# Altium schematic sheet PHOTODIODE.SchDoc
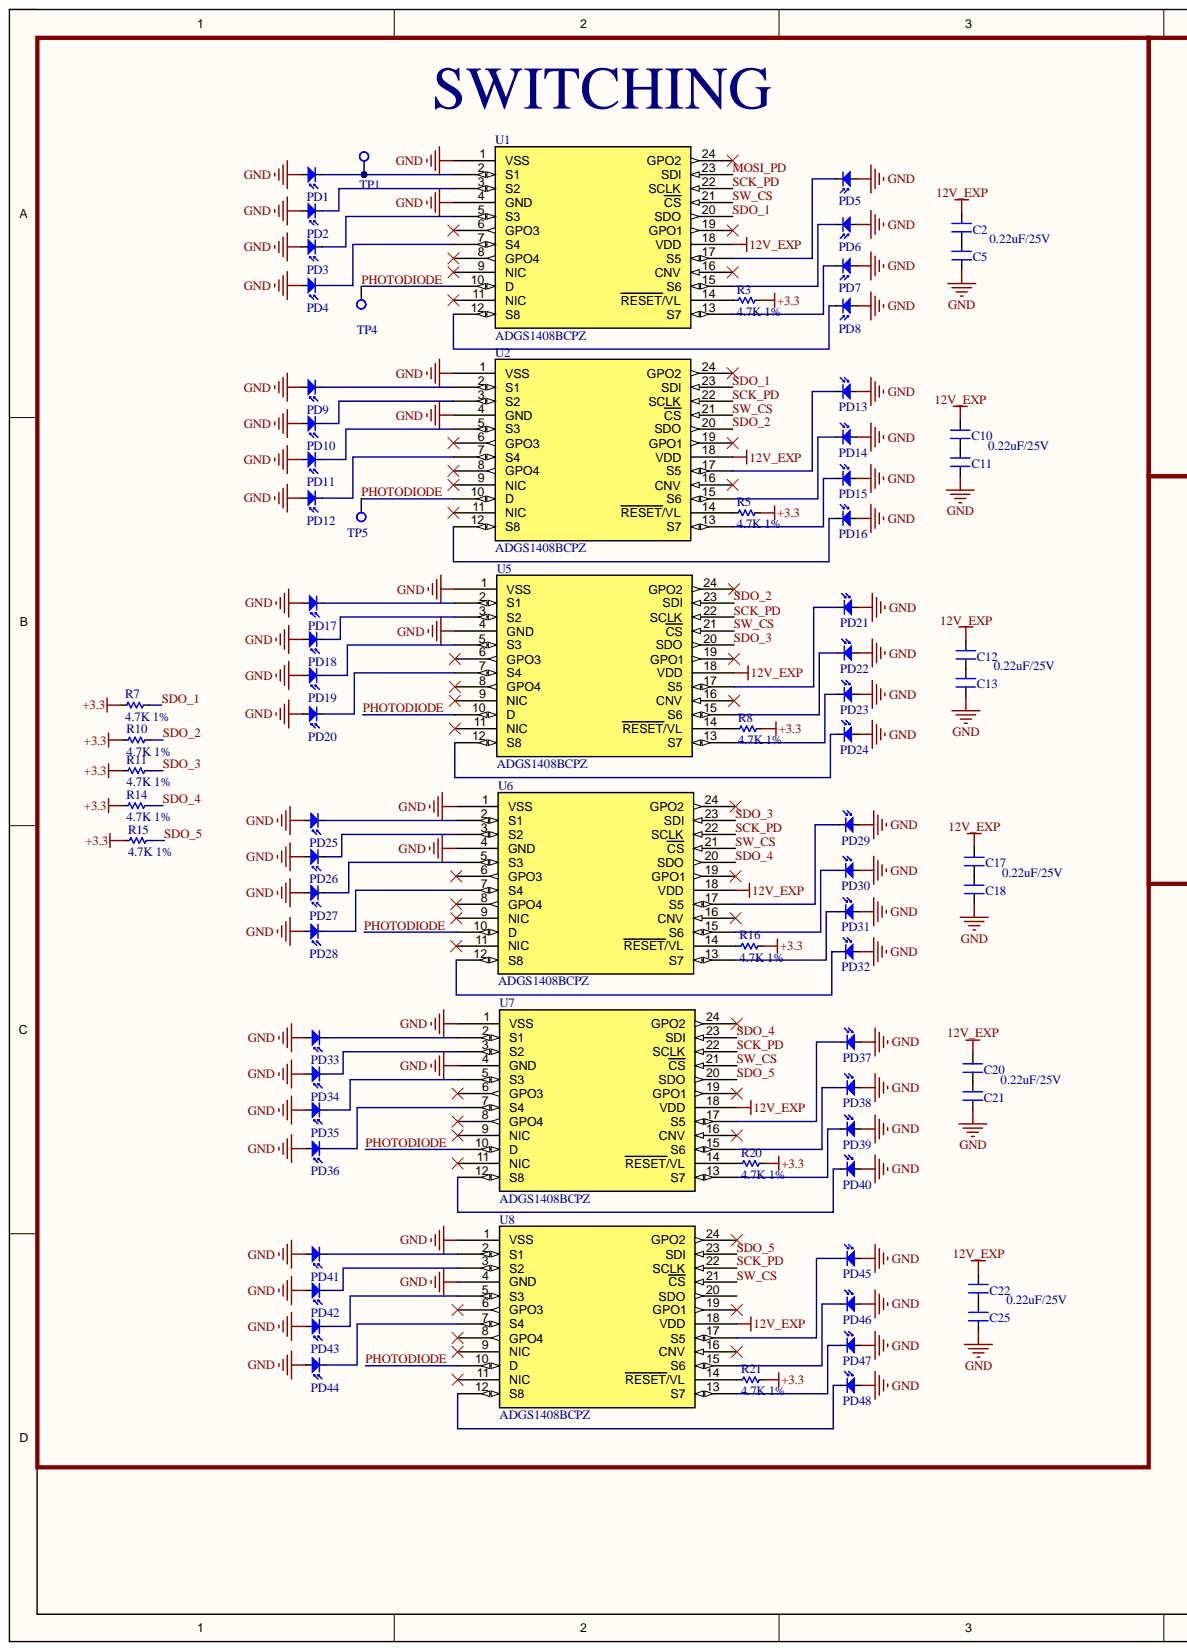
[diagram p0: PHOTODIODE.SchDoc, left]
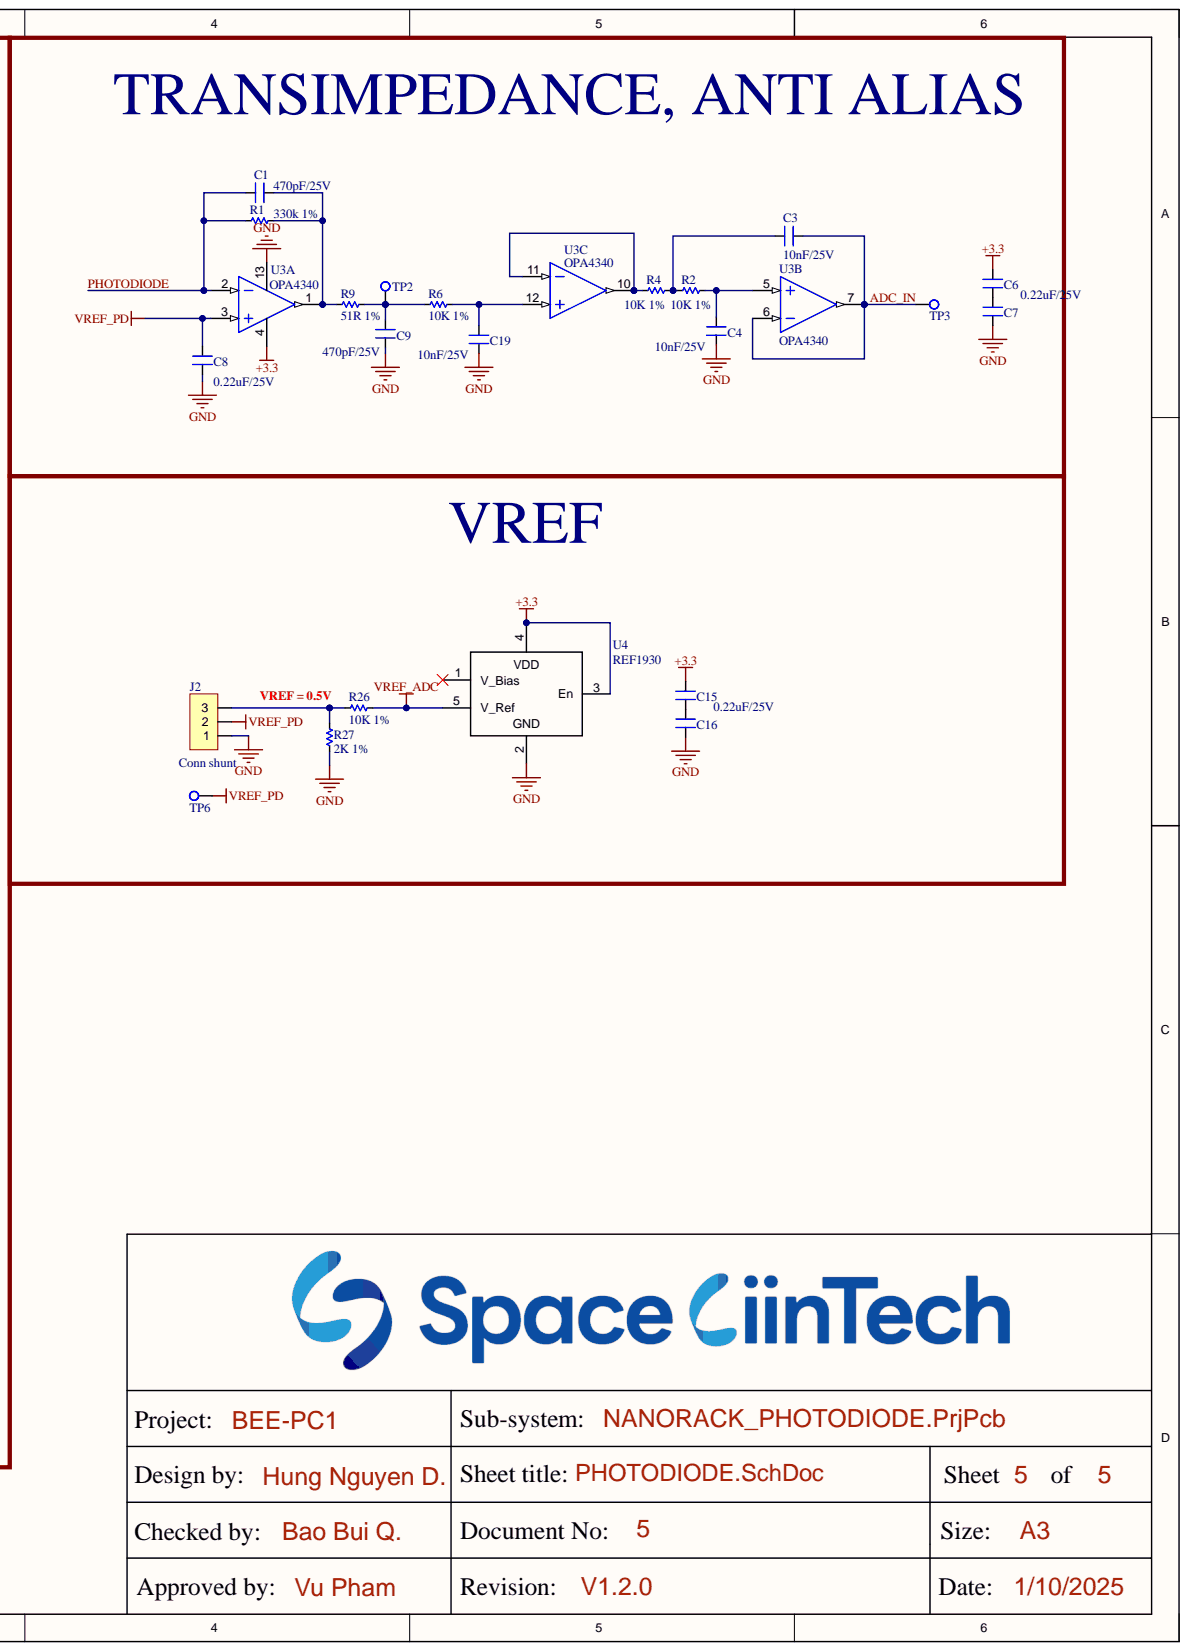
[diagram p1: PHOTODIODE.SchDoc, right]

## Parts
C1  470pF/25V  [SM-0603]
C2  0.22uF/25V  [SM-0603]
C3  10nF/25V  [SM-0603]
C4  10nF/25V  [SM-0603]
C5  0.22uF/25V  [SM-0603]
C6  0.22uF/25V  [SM-0603]
C7  0.22uF/25V  [SM-0603]
C8  0.22uF/25V  [SM-0603]
C9  470pF/25V  [SM-0603]
C10  0.22uF/25V  [SM-0603]
C11  0.22uF/25V  [SM-0603]
C12  0.22uF/25V  [SM-0603]
C13  0.22uF/25V  [SM-0603]
C15  0.22uF/25V  [SM-0603]
C16  0.22uF/25V  [SM-0603]
C17  0.22uF/25V  [SM-0603]
C18  0.22uF/25V  [SM-0603]
C19  10nF/25V  [SM-0603]
C20  0.22uF/25V  [SM-0603]
C21  0.22uF/25V  [SM-0603]
C22  0.22uF/25V  [SM-0603]
C25  0.22uF/25V  [SM-0603]
J2  Conn shunt  [M50-3930342]
PD1  Photodiode  [VEMD5080X01]  (value not drawn)
PD2  Photodiode  [VEMD5080X01]  (value not drawn)
PD3  Photodiode  [VEMD5080X01]  (value not drawn)
PD4  Photodiode  [VEMD5080X01]  (value not drawn)
PD5  Photodiode  [VEMD5080X01]  (value not drawn)
PD6  Photodiode  [VEMD5080X01]  (value not drawn)
PD7  Photodiode  [VEMD5080X01]  (value not drawn)
PD8  Photodiode  [VEMD5080X01]  (value not drawn)
PD9  Photodiode  [VEMD5080X01]  (value not drawn)
PD10  Photodiode  [VEMD5080X01]  (value not drawn)
PD11  Photodiode  [VEMD5080X01]  (value not drawn)
PD12  Photodiode  [VEMD5080X01]  (value not drawn)
PD13  Photodiode  [VEMD5080X01]  (value not drawn)
PD14  Photodiode  [VEMD5080X01]  (value not drawn)
PD15  Photodiode  [VEMD5080X01]  (value not drawn)
PD16  Photodiode  [VEMD5080X01]  (value not drawn)
PD17  Photodiode  [VEMD5080X01]  (value not drawn)
PD18  Photodiode  [VEMD5080X01]  (value not drawn)
PD19  Photodiode  [VEMD5080X01]  (value not drawn)
PD20  Photodiode  [VEMD5080X01]  (value not drawn)
PD21  Photodiode  [VEMD5080X01]  (value not drawn)
PD22  Photodiode  [VEMD5080X01]  (value not drawn)
PD23  Photodiode  [VEMD5080X01]  (value not drawn)
PD24  Photodiode  [VEMD5080X01]  (value not drawn)
PD25  Photodiode  [VEMD5080X01]  (value not drawn)
PD26  Photodiode  [VEMD5080X01]  (value not drawn)
PD27  Photodiode  [VEMD5080X01]  (value not drawn)
PD28  Photodiode  [VEMD5080X01]  (value not drawn)
PD29  Photodiode  [VEMD5080X01]  (value not drawn)
PD30  Photodiode  [VEMD5080X01]  (value not drawn)
PD31  Photodiode  [VEMD5080X01]  (value not drawn)
PD32  Photodiode  [VEMD5080X01]  (value not drawn)
PD33  Photodiode  [VEMD5080X01]  (value not drawn)
PD34  Photodiode  [VEMD5080X01]  (value not drawn)
PD35  Photodiode  [VEMD5080X01]  (value not drawn)
PD36  Photodiode  [VEMD5080X01]  (value not drawn)
PD37  Photodiode  [VEMD5080X01]  (value not drawn)
PD38  Photodiode  [VEMD5080X01]  (value not drawn)
PD39  Photodiode  [VEMD5080X01]  (value not drawn)
PD40  Photodiode  [VEMD5080X01]  (value not drawn)
PD41  Photodiode  [VEMD5080X01]  (value not drawn)
PD42  Photodiode  [VEMD5080X01]  (value not drawn)
PD43  Photodiode  [VEMD5080X01]  (value not drawn)
PD44  Photodiode  [VEMD5080X01]  (value not drawn)
PD45  Photodiode  [VEMD5080X01]  (value not drawn)
PD46  Photodiode  [VEMD5080X01]  (value not drawn)
PD47  Photodiode  [VEMD5080X01]  (value not drawn)
PD48  Photodiode  [VEMD5080X01]  (value not drawn)
R1  330k 1%  [RES-SMD-0603]
R2  10K 1%  [RES-SMD-0603]
R3  4.7K 1%  [RES-SMD-0603]
R4  10K 1%  [RES-SMD-0603]
R5  4.7K 1%  [RES-SMD-0603]
R6  10K 1%  [RES-SMD-0603]
R7  4.7K 1%  [RES-SMD-0603]
R8  4.7K 1%  [RES-SMD-0603]
R9  51R 1%  [RES-SMD-0603]
R10  4.7K 1%  [RES-SMD-0603]
R11  4.7K 1%  [RES-SMD-0603]
R14  4.7K 1%  [RES-SMD-0603]
R15  4.7K 1%  [RES-SMD-0603]
R16  4.7K 1%  [RES-SMD-0603]
R20  4.7K 1%  [RES-SMD-0603]
R21  4.7K 1%  [RES-SMD-0603]
R26  10K 1%  [RES-SMD-0603]
R27  2K 1%  [RES-SMD-0603]
TP1  Test_Point  [TESTPOINT]  (value not drawn)
TP2  Test_Point  [TESTPOINT]  (value not drawn)
TP3  Test_Point  [TESTPOINT]  (value not drawn)
TP4  Test_Point  [TESTPOINT]  (value not drawn)
TP5  Test_Point  [TESTPOINT]  (value not drawn)
TP6  Test_Point  [TESTPOINT]  (value not drawn)
U1  ADGS1408BCPZ  [ADGS1408BCPZ]
U2  ADGS1408BCPZ  [ADGS1408BCPZ]
U3  OPA4340  [SSOP16]  (parts A,B,C)
U4  REF1930  [SOT23-5]
U5  ADGS1408BCPZ  [ADGS1408BCPZ]
U6  ADGS1408BCPZ  [ADGS1408BCPZ]
U7  ADGS1408BCPZ  [ADGS1408BCPZ]
U8  ADGS1408BCPZ  [ADGS1408BCPZ]

## Nets
12V_EXP: C2.2 C10.2 C12.2 C17.2 C20.2 C22.2 U1.18(VDD) U2.18(VDD) U5.18(VDD) U6.18(VDD) U7.18(VDD) U8.18(VDD)
+3.3: C6.2 C15.2 R3.2 R5.2 R7.2 R8.2 R10.2 R11.2 R14.2 R15.2 R16.2 R20.2 R21.2 U3.4 U4.3(En) U4.4
GND: C4.1 C5.1 C7.1 C8.1 C9.1 C11.1 C13.1 C16.1 C18.1 C19.1 C21.1 C25.1 J2.1 PD1.1 PD2.1 PD3.1 PD4.1 PD5.1 PD6.1 PD7.1 PD8.1 PD9.1 PD10.1 PD11.1 PD12.1 PD13.1 PD14.1 PD15.1 PD16.1 PD17.1 PD18.1 PD19.1 PD20.1 PD21.1 PD22.1 PD23.1 PD24.1 PD25.1 PD26.1 PD27.1 PD28.1 PD29.1 PD30.1 PD31.1 PD32.1 PD33.1 PD34.1 PD35.1 PD36.1 PD37.1 PD38.1 PD39.1 PD40.1 PD41.1 PD42.1 PD43.1 PD44.1 PD45.1 PD46.1 PD47.1 PD48.1 R27.1 U1.1(VSS) U1.4(GND) U2.1(VSS) U2.4(GND) U3.13 U4.2 U5.1(VSS) U5.4(GND) U6.1(VSS) U6.4(GND) U7.1(VSS) U7.4(GND) U8.1(VSS) U8.4(GND)
VREF_ADC: R26.1 U4.5(V_Ref)
VREF_PD: C8.2 J2.2 TP6.1 U3.3
ADC_IN: C3.1 TP3.1 U3.6 U3.7
MOSI_PD: U1.23(SDI)
PHOTODIODE: C1.1 R1.1 TP4.1 TP5.1 U1.10(D) U2.10(D) U3.2 U5.10(D) U6.10(D) U7.10(D) U8.10(D)
SCK_PD: U1.22(SCLK) U2.22(SCLK) U5.22(SCLK) U6.22(SCLK) U7.22(SCLK) U8.22(SCLK)
SDO_1: R7.1 U1.20(SDO) U2.23(SDI)
SDO_2: R10.1 U2.20(SDO) U5.23(SDI)
SDO_3: R11.1 U5.20(SDO) U6.23(SDI)
SDO_4: R14.1 U6.20(SDO) U7.23(SDI)
SDO_5: R15.1 U7.20(SDO) U8.23(SDI)
SW_CS: U1.21(CS) U2.21(CS) U5.21(CS) U6.21(CS) U7.21(CS) U8.21(CS)
NetC1_2: C1.2 R1.2 R9.1 U3.1
NetC2_1: C2.1 C5.2
NetC3_2: C3.2 R2.1 R4.2
NetC4_2: C4.2 R2.2 U3.5
NetC6_1: C6.1 C7.2
NetC9_2: C9.2 R6.1 R9.2 TP2.1
NetC10_1: C10.1 C11.2
NetC12_1: C12.1 C13.2
NetC15_1: C15.1 C16.2
NetC17_1: C17.1 C18.2
NetC19_2: C19.2 R6.2 U3.12
NetC20_1: C20.1 C21.2
NetC22_1: C22.1 C25.2
NetJ2_3: J2.3 R26.2 R27.2
NetPD1_2: PD1.2 TP1.1 U1.2(S1)
NetPD2_2: PD2.2 U1.3(S2)
NetPD3_2: PD3.2 U1.5(S3)
NetPD4_2: PD4.2 U1.7(S4)
NetPD5_2: PD5.2 U1.17(S5)
NetPD6_2: PD6.2 U1.15(S6)
NetPD7_2: PD7.2 U1.13(S7)
NetPD8_2: PD8.2 U1.12(S8)
NetPD9_2: PD9.2 U2.2(S1)
NetPD10_2: PD10.2 U2.3(S2)
NetPD11_2: PD11.2 U2.5(S3)
NetPD12_2: PD12.2 U2.7(S4)
NetPD13_2: PD13.2 U2.17(S5)
NetPD14_2: PD14.2 U2.15(S6)
NetPD15_2: PD15.2 U2.13(S7)
NetPD16_2: PD16.2 U2.12(S8)
NetPD17_2: PD17.2 U5.2(S1)
NetPD18_2: PD18.2 U5.3(S2)
NetPD19_2: PD19.2 U5.5(S3)
NetPD20_2: PD20.2 U5.7(S4)
NetPD21_2: PD21.2 U5.17(S5)
NetPD22_2: PD22.2 U5.15(S6)
NetPD23_2: PD23.2 U5.13(S7)
NetPD24_2: PD24.2 U5.12(S8)
NetPD25_2: PD25.2 U6.2(S1)
NetPD26_2: PD26.2 U6.3(S2)
NetPD27_2: PD27.2 U6.5(S3)
NetPD28_2: PD28.2 U6.7(S4)
NetPD29_2: PD29.2 U6.17(S5)
NetPD30_2: PD30.2 U6.15(S6)
NetPD31_2: PD31.2 U6.13(S7)
NetPD32_2: PD32.2 U6.12(S8)
NetPD33_2: PD33.2 U7.2(S1)
NetPD34_2: PD34.2 U7.3(S2)
NetPD35_2: PD35.2 U7.5(S3)
NetPD36_2: PD36.2 U7.7(S4)
NetPD37_2: PD37.2 U7.17(S5)
NetPD38_2: PD38.2 U7.15(S6)
NetPD39_2: PD39.2 U7.13(S7)
NetPD40_2: PD40.2 U7.12(S8)
NetPD41_2: PD41.2 U8.2(S1)
NetPD42_2: PD42.2 U8.3(S2)
NetPD43_2: PD43.2 U8.5(S3)
NetPD44_2: PD44.2 U8.7(S4)
NetPD45_2: PD45.2 U8.17(S5)
NetPD46_2: PD46.2 U8.15(S6)
NetPD47_2: PD47.2 U8.13(S7)
NetPD48_2: PD48.2 U8.12(S8)
NetR3_1: R3.1 U1.14(RESET/VL)
NetR4_1: R4.1 U3.10 U3.11
NetR5_1: R5.1 U2.14(RESET/VL)
NetR8_1: R8.1 U5.14(RESET/VL)
NetR16_1: R16.1 U6.14(RESET/VL)
NetR20_1: R20.1 U7.14(RESET/VL)
NetR21_1: R21.1 U8.14(RESET/VL)

Not connected: U1 6(GPO3) 8(GPO4) 9(NIC) 11(NIC) 16(CNV) 19(GPO1) 24(GPO2); U2 6(GPO3) 8(GPO4) 9(NIC) 11(NIC) 16(CNV) 19(GPO1) 24(GPO2); U4 1(V_Bias); U5 6(GPO3) 8(GPO4) 9(NIC) 11(NIC) 16(CNV) 19(GPO1) 24(GPO2); U6 6(GPO3) 8(GPO4) 9(NIC) 11(NIC) 16(CNV) 19(GPO1) 24(GPO2); U7 6(GPO3) 8(GPO4) 9(NIC) 11(NIC) 16(CNV) 19(GPO1) 24(GPO2); U8 6(GPO3) 8(GPO4) 9(NIC) 11(NIC) 16(CNV) 19(GPO1) 20(SDO) 24(GPO2)

## Library data of the parts
C1-C13 C15-C22 C25 = CM: 0603; Value: 103; Component Kind: Standard; Library Name: Capacitors.SchLib
J2 = JP3: WR-PHD 2.54 mm Angled Socket Header; Manufacturer: W¨¹rth Elektronik eiSos GmbH; partNo: 613003143121; ComponentLink1 Description: Manufacturer; ComponentLink2 Description: Datasheet; NoPins: 03; Component Kind: Standard; ComponentLink1URL: http://www.we-online.com; ComponentLink2URL: http://katalog.we-online.de/em/datasheet/6130xx143121.pdf; Created Date: 29 March 2013; Library Name: Library.SchLib; Created by: Karrer Zheng
R1-R11 R14-R16 R20 R21 R26 R27 = Res-SMD: 0603; Manufacturer: Generic Components; Class: Passive; Component Kind: Standard; Library Name: Library.SchLib; Published: 08/06/2000; Publisher: Altium Hobart Technology Centre
U1 U2 U5-U8 = ADGS1408: IC MUX 8:1 4.7OHM 24LFCSP; Component Kind: Standard; Library Name: Library.SchLib
U3 = OPA4340: IC CMOS 4 CIRCUIT 16SSOP; Component Kind: Standard; Library Name: Library.SchLib; Supplier 1: Mouser; Supplier Part Number 1: 595-OPA4340UA/2K5
U4 = REF19xx: REF1941AIDDCT; Component Kind: Standard; Library Name: Library.SchLib
PD1-PD48 = Photodiode: Component Kind: Standard; Library Name: Library_HUNG.SchLib
TP1-TP6 = Test_Point: Component Kind: Standard; Library Name: Library_HUNG.SchLib
